# Altium schematic sheet BluePhilSch.SchDoc(BluePhilSch)
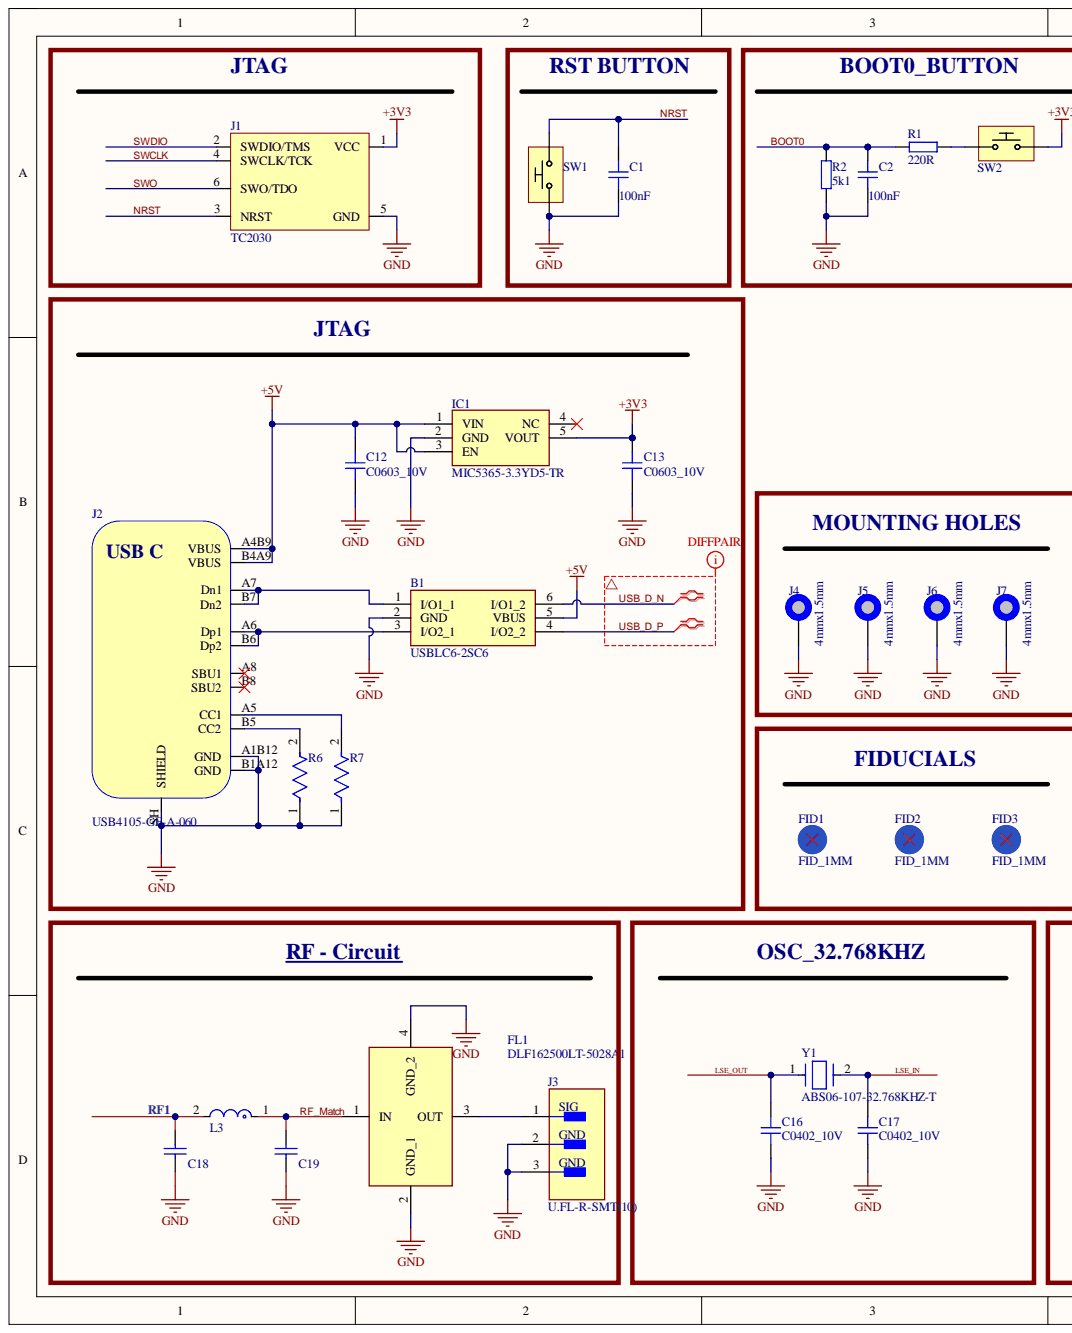
[diagram p0: BluePhilSch.SchDoc, left]
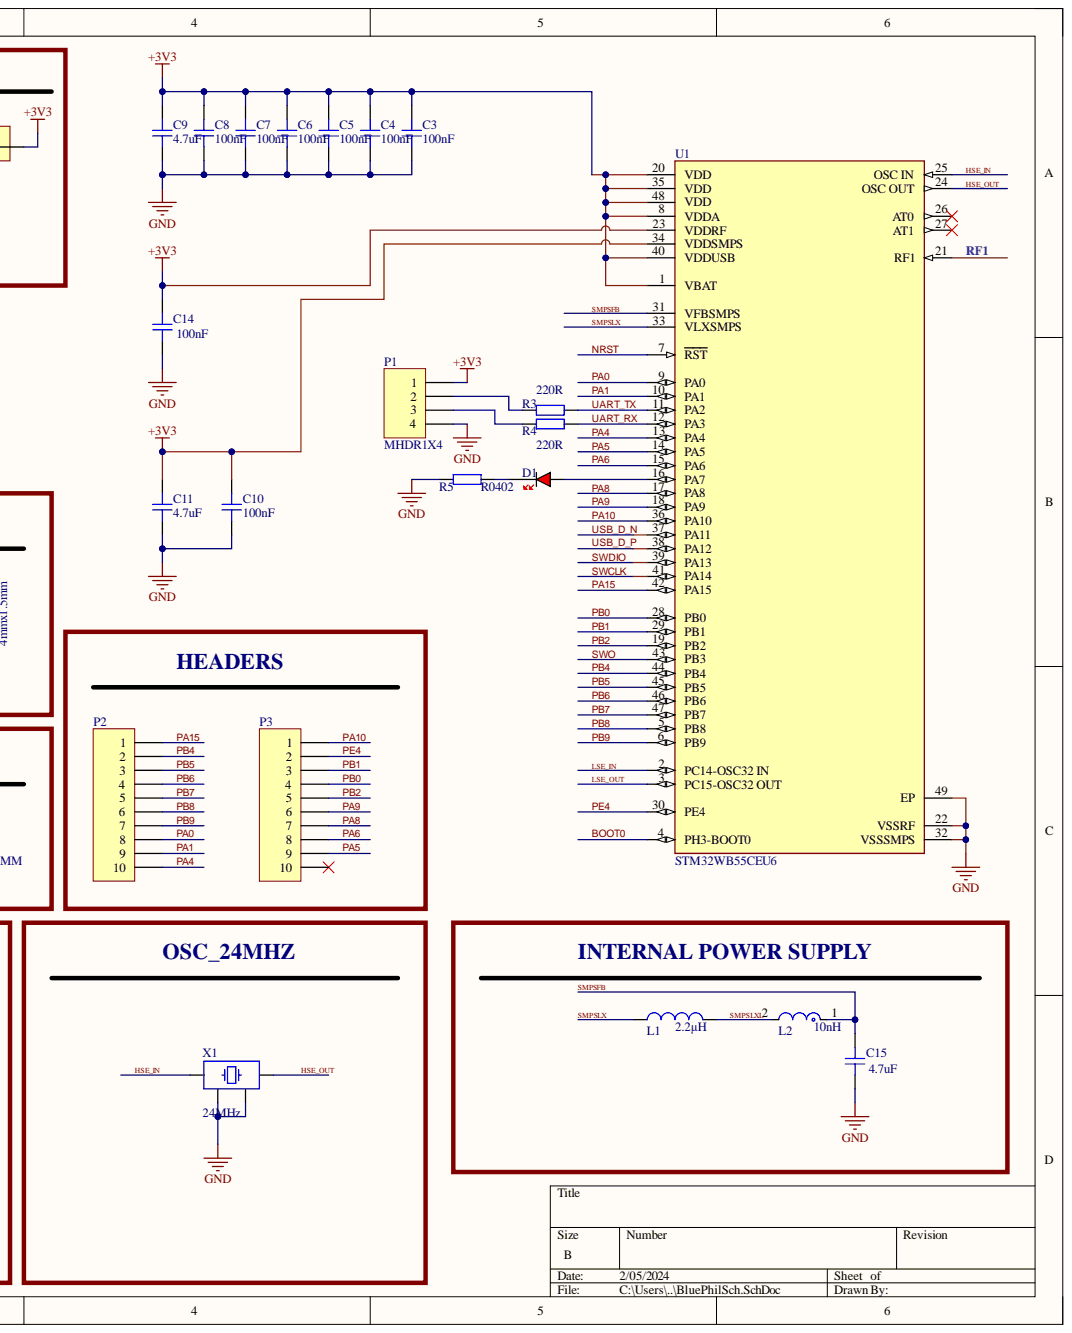
[diagram p1: BluePhilSch.SchDoc, right]

## Parts
B1  USBLC6-2SC6  [SOT95P280X145-6N]
C1  C0402_10V  value 100nF  [CAP_0402]
C2  C0402_10V  value 100nF  [CAP_0402]
C3  C0402_10V  value 100nF  [CAP_0402]
C4  C0402_10V  value 100nF  [CAP_0402]
C5  C0402_10V  value 100nF  [CAP_0402]
C6  C0402_10V  value 100nF  [CAP_0402]
C7  C0402_10V  value 100nF  [CAP_0402]
C8  C0402_10V  value 100nF  [CAP_0402]
C9  C0402_10V  value 4.7uF  [CAP_0402]
C10  C0402_10V  value 100nF  [CAP_0402]
C11  C0402_10V  value 4.7uF  [CAP_0402]
C12  C0603_10V  [CAP_0603]
C13  C0603_10V  [CAP_0603]
C14  C0402_10V  value 100nF  [CAP_0402]
C15  C0402_10V  value 4.7uF  [CAP_0402]
C16  C0402_10V  [CAP_0402]
C17  C0402_10V  [CAP_0402]
C18  GRM1555C1HR80BA01D  [CAPC1005X55X25LL05T10]  (value not drawn)
C19  GRM1555C1HR30WA01D  [CAPC1005X55X25NL05T10]  (value not drawn)
D1  RED  [LED_0603_RED]  (value not drawn)
FID1  FID_1MM  [FID_40X120D]
FID2  FID_1MM  [FID_40X120D]
FID3  FID_1MM  [FID_40X120D]
FL1  DLF162500LT-5028A1  [DLF162500LT5028A1]
IC1  MIC5365-3.3YD5-TR  [SOT95P280X100-5N]
J1  TC2030  [TC2030-FP]  (no BOM)
J2  USB4105-GF-A-060  [USB4105-GF-A-060]
J3  U.FL-R-SMT(10)  [FP-U_FL-R-SMT_10-MFG]
J4  4mmx1.5mm  [PAD_WITH_HOLE_4mmx1.5mm]  (no BOM)
J5  4mmx1.5mm  [PAD_WITH_HOLE_4mmx1.5mm]  (no BOM)
J6  4mmx1.5mm  [PAD_WITH_HOLE_4mmx1.5mm]  (no BOM)
J7  4mmx1.5mm  [PAD_WITH_HOLE_4mmx1.5mm]  (no BOM)
L1  Inductance: 2.2µH  [WE-PMI_0805_L2W1.2H0.5]  (hidden comment: 74479774222)
L2  Inductance: 10nH  [FP-LQG15WH_02-MFG]  (hidden comment: LQG15WZ10NJ02D)
L3  LQG15HS2N7S02D  [FP-LQG15HH_02-IPC_C]  (value not drawn)
P1  MHDR1X4  [MHDR1X4body]
P2  Header 10  [MY_MA10-1]  (value not drawn)
P3  Header 10  [MY_MA10-1]  (value not drawn)
R1  220R  [RES_0402]
R2  5k1  [RES_0402]
R3  220R  [RES_0402]
R4  220R  [RES_0402]
R5  R0402  [RES_0402]
R6  ERJ-2RKF5101X  [FP-ERJ2RK-IPC_A]  (value not drawn)
R7  ERJ-2RKF5101X  [FP-ERJ2RK-IPC_A]  (value not drawn)
SW1  Tactile Switch  [PTS815]  (value not drawn)
SW2  Tactile Switch  [PTS815]  (value not drawn)
U1  STM32WB55CEU6  [FP-UFQFPN48-A0B9-MFG]
X1  24MHz  [XTAL_3225]
Y1  ABS06-107-32.768KHZ-T  [FP-ABS06-MFG]

## Nets
+3V3: C3.1 C4.1 C5.1 C6.1 C7.1 C8.1 C9.1 C10.1 C11.1 C13.2 C14.1 IC1.5(VOUT) J1.1(VCC) P1.1 SW2.2 U1.1(VBAT) U1.8(VDDA) U1.20(VDD) U1.23(VDDRF) U1.34(VDDSMPS) U1.35(VDD) U1.40(VDDUSB) U1.48(VDD)
+5V: B1.5(VBUS) C12.2 IC1.1(VIN) IC1.3(EN) J2.A4B9(VBUS) J2.B4A9(VBUS)
GND: B1.2(GND) C1.2 C2.2 C3.2 C4.2 C5.2 C6.2 C7.2 C8.2 C9.2 C10.2 C11.2 C12.1 C13.1 C14.2 C15.2 C16.1 C17.1 C18.1 C19.2 FL1.2(GND_1) FL1.4(GND_2) IC1.2(GND) J1.5(GND) J2.A1B12(GND) J2.B1A12(GND) J2.SH(SHIELD) J3.2 J3.3 J4.1 J5.1 J6.1 J7.1 P1.4 R2.2 R5.1 R6.1 R7.1 SW1.1 U1.22(VSSRF) U1.32(VSSSMPS) U1.49(EP) X1.2 X1.4
BOOT0: C2.1 R1.1 R2.1 U1.4(PH3-BOOT0)
HSE_IN: U1.25(OSC_IN) X1.1
HSE_OUT: U1.24(OSC_OUT) X1.3
LSE_IN: C17.2 U1.2(PC14-OSC32_IN) Y1.2
LSE_OUT: C16.2 U1.3(PC15-OSC32_OUT) Y1.1
NRST: C1.1 J1.3(NRST) SW1.2 U1.7(RST)
PA0: P2.8 U1.9(PA0)
PA1: P2.9 U1.10(PA1)
PA4: P2.10 U1.13(PA4)
PA5: P3.9 U1.14(PA5)
PA6: P3.8 U1.15(PA6)
PA8: P3.7 U1.17(PA8)
PA9: P3.6 U1.18(PA9)
PA10: P3.1 U1.36(PA10)
PA15: P2.1 U1.42(PA15)
PB0: P3.4 U1.28(PB0)
PB1: P3.3 U1.29(PB1)
PB2: P3.5 U1.19(PB2)
PB4: P2.2 U1.44(PB4)
PB5: P2.3 U1.45(PB5)
PB6: P2.4 U1.46(PB6)
PB7: P2.5 U1.47(PB7)
PB8: P2.6 U1.5(PB8)
PB9: P2.7 U1.6(PB9)
PE4: P3.2 U1.30(PE4)
RF1: C18.2 L3.2 U1.21(RF1)
RF_Match: C19.1 FL1.1(IN) L3.1
SMPSFB: C15.1 L2.1 U1.31(VFBSMPS)
SMPSLX: L1.2 U1.33(VLXSMPS)
SMPSLXL: L1.1 L2.2
SWCLK: J1.4(SWCLK/TCK) U1.41(PA14)
SWDIO: J1.2(SWDIO/TMS) U1.39(PA13)
SWO: J1.6(SWO/TDO) U1.43(PB3)
UART_RX: R4.2 U1.12(PA3)
UART_TX: R3.2 U1.11(PA2)
USB_D_N: B1.6(I/O1_2) U1.37(PA11)
USB_D_P: B1.4(I/O2_2) U1.38(PA12)
NetB1_1: B1.1(I/O1_1) J2.A7(Dn1) J2.B7(Dn2)
NetB1_3: B1.3(I/O2_1) J2.A6(Dp1) J2.B6(Dp2)
NetD1_A: D1.A U1.16(PA7)
NetD1_K: D1.K R5.2
NetFL1_3: FL1.3(OUT) J3.1
NetJ2_A5: J2.A5(CC1) R7.2
NetJ2_B5: J2.B5(CC2) R6.2
NetP1_2: P1.2 R3.1
NetP1_3: P1.3 R4.1
NetR1_2: R1.2 SW2.1

Not connected: IC1 4(NC); J2 A8(SBU1) B8(SBU2); P3 10; U1 26(AT0) 27(AT1)

## Library data of the parts
B1 = USBLC6-2SC6: TVS Diode Array Uni-Directional USBLC6-2SC6 17V, SOT-23 6-Pin; Manufacturer_Name: STMicroelectronics; Geometry.Height: 1.45mm; Component Kind: Standard; Datasheet Link: http://www.st.com/st-web-ui/static/active/en/resource/technical/document/datasheet/CD00050750.pdf; Library Name: USBLC6-2SC6.SchLib; Manufacturer_Part_Number: USBLC6-2SC6; Mouser Part Number: 511-USBLC6-2SC6; Mouser Price/Stock: https://www.mouser.co.uk/ProductDetail/STMicroelectronics/USBLC6-2SC6?qs=po45yt2pPpu%2FhNIlwQdTlg%3D%3D
C1-C11 C14-C17 = CAP_0402_10V: Capacitor SMD 0402 10V; Component Kind: Standard; Library Name: Schematic-Lib-PhilsLab.SchLib
C12 C13 = CAP_0603_10V: Capacitor SMD 0603 10V; Component Kind: Standard; Library Name: Schematic-Lib-PhilsLab.SchLib
C18 = CMP-1034-00043-2: Manufacturer: Murata; Component Kind: Standard; Library Name: Altium Content Vault; Part Number: GRM1555C1HR80BA01D
C19 = CMP-1034-00007-2: Manufacturer: Murata; Component Kind: Standard; Library Name: Altium Content Vault; Part Number: GRM1555C1HR30WA01D
D1 = LED_0603_RED: LED 0603 RED SMD; Component Kind: Standard; Library Name: Schematic-Lib-PhilsLab.SchLib
FL1 = DLF162500LT-5028A1: Filter; Manufacturer_Name: TDK; Arrow Part Number: DLF162500LT-5028A1; Arrow Price/Stock: https://www.arrow.com/en/products/dlf162500lt-5028a1/tdk?region=europe; Component Kind: Standard; Datasheet Link: https://product.tdk.com/system/files/dam/doc/product/rf/rf/filter/catalog/rf_lpf_dlf162500lt-5028a1_en.pdf; Height: 0.4mm; Manufacturer_Part_Number: DLF162500LT-5028A1; Mouser Part Number: 810-DLF16250LT5028A1; Mouser Price/Stock: https://www.mouser.co.uk/ProductDetail/TDK/DLF162500LT-5028A1?qs=U%2FZX79kHR%2FlwIbAISCs3qA%3D%3D
IC1 = MIC5365-3.3YD5-TR: Integrated Circuit; Manufacturer_Name: Microchip; Arrow Part Number: MIC5365-3.3YD5-TR; Arrow Price/Stock: https://www.arrow.com/en/products/mic5365-3.3yd5-tr/microchip-technology?region=nac; Component Kind: Standard; Datasheet Link: https://ww1.microchip.com/downloads/en/DeviceDoc/mic5365.pdf; Height: 1mm; Manufacturer_Part_Number: MIC5365-3.3YD5-TR; Mouser Part Number: 998-MIC5365-3.3YD5TR; Mouser Price/Stock: https://www.mouser.co.uk/ProductDetail/Microchip-Technology/MIC5365-3.3YD5-TR?qs=U6T8BxXiZAXzRinbbfZRrg%3D%3D
J1 = TC2030: Tag-Connect No-Solder SWD Debug Connector DO NOT PLACE; Manufacturer: Tag-Connect; Manufacturer Part Number: TC2030; Library Name: Schematic-Lib-PhilsLab.SchLib
J2 = USB4105-GF-A-060: USB Connector Type C SMT 12 Pin; Manufacturer: GCT; Component Kind: Standard; Manufacturer Part Number: USB4105-GF-A-060; Library Name: Schematic-Lib-PhilsLab.SchLib
J3 = CMP-0029-00003-2: CONN U.FL RCPT STR 50 OHM SMD; Body Material: Bronze; Body Plating: Silver; Connector Type: Coaxial; Dielectric Material: Polymer; Frequency: 6GHz; Impedance: 50R; Case/Package: SMD/SMT; Component Kind: Standard; Contact Material: Brass; Contact Plating: Gold; Depth: 3.1mm; Fastening Type: Snap; Housing Color: Beige; Lead Free: Lead Free; Length: 3mm; Library Name: Altium Content Vault; Max Frequency: 6GHz; Max Operating Temperature: 90°C; Min Operating Temperature: -40°C; Mount: Surface Mount; Orientation: Vertical; Package Quantity: 1; Packaging: Tape and Reel; Plating: Silver; Radiation Hardening: No; Termination: Solder
J4-J7 = PAD_WITH_HOLE_4mmx1.5mm: Library Name: Schlib1.SchLib
L1 = CMP-1392-00044-3: NexarPartId: 23392509; Current Rating: 700mA; DC Resistance (DCR): 340mΩ; Inductance Tolerance: 20%; Q Factor: 15; Saturation Current: 250mA; Self Resonant Frequency: 40MHz; Series Resistance: 425mΩ; Test Frequency: 1MHz; Ambient Temperature Range High: 85°C; Ambient Temperature Range Low: -40°C; Case/Package: 0805; Component Kind: Standard; Height: 500µm; Length: 2mm; Library Name: Altium Content Vault; Max Operating Temperature: 125°C; Min Operating Temperature: -40°C; Number of Pins: 2; Packaging: Digi-Reel®; RMS Current (Irms): 700mA; RoHS: Compliant; Tolerance: 20%; Width: 1.25mm
L2 = CMP-06042-005237-1: Multilayer type Inductor for Automotive 10nH ±5% 0.19Ω 500mA 0402; Core Material: Air; DC Resistance (DCR): 190mR; Self Resonant Frequency: 3.3GHz; Shielding: Unshielded; Test Frequency: 100MHz; Case Code (Imperial): 0402; Case Code (Metric): 1005; Case/Package: 0402; Component Kind: Standard; Current Rating: 500mA; Library Name: Altium Content Vault; Max Operating Temperature: 125°C; Min Operating Temperature: -55°C; Mount: Surface Mount; Packaging: Cut Tape; Ratings: AEC-Q200; Tolerance: 5%
L3 = CMP-2000-06580-2: Multilayer type RF Inductor 2.7nH ±0.3nH 0.12Ω 800mA 0402; Core Material: Air; Current Rating: 300mA; DC Resistance (DCR): 150mR; Q Factor: 8; Self Resonant Frequency: 6GHz; Shielding: Unshielded; Test Frequency: 100MHz; Case Code (Imperial): 0402; Case Code (Metric): 1005; Case/Package: 0402; Component Kind: Standard; DC Current: 300mA; Depth: 0.55mm; Height: 0.5mm; Height - Seated (Max): 0.022inch; Inductance: 2.7nH; Lead Free: Lead Free; Length: 1mm; Library Name: Altium Content Vault; Max DC Current: 300mA; Max Operating Temperature: 125°C; Military Standard: Not; Min Operating Temperature: -55°C; Mount: Surface Mount; Package Quantity: 10000; Packaging: Tape and Reel; Radiation Hardening: No; Termination: SMD/SMT; Width: 0.5mm
P1 = MHDR1X4: Header, 4-Pin; Component Kind: Standard; LatestRevisionDate: 17-Jul-2002; LatestRevisionNote: Re-released for DXP Platform.; Library Name: smartmcu.IntLib; Publisher: Altium Limited
P2 P3 = Header 10: Header, 10-Pin; ASiD Part Number: Header 10-AS; Component Kind: Standard; Library Name: Mylib+Rev+4.IntLib; Signal Integrity: Connector
R1-R5 = RES_0402: Resistor SMD 0402; Component Kind: Standard; Library Name: Schematic-Lib-PhilsLab.SchLib
R6 R7 = CMP-2002-01158-2: RES SMD 5.1K OHM 1% 1/10W 0402; Max Power Dissipation: 100mW; Resistance: 5.1kR; Temperature Coefficient: 100ppm/°C; Voltage Rating: 50V; Case Code (Imperial): 0402; Case Code (Metric): 1005; Case/Package: 0402; Component Kind: Standard; Construction: Rectangular; Contact Plating: Tin; Depth: 0.5mm; Height: 0.35mm; Lead Free: Lead Free; Length: 1mm; Library Name: Altium Content Vault; Max Operating Temperature: 155°C; Military Standard: Not; Min Operating Temperature: -55°C; Mount: Surface Mount; Number of Terminations: 2; Package Quantity: 10000; Packaging: Tape and Reel; Power Rating: 100mW; REACH SVHC: No SVHC; Resistance Tolerance: 1%; Resistor Type: Thick Film; RoHS Compliant: Yes; Series: ERJ; Technology: THICK FILM; Termination: SMD/SMT; Tolerance: 1%; Voltage Rating (DC): 50V; Weight: 2.8E-05oz; Width: 0.5mm
SW1 SW2 = PTS815-SJM-250-SMTR-LFS: Tactile Switches Tact Switch, 12 VDC, 50 mA, 4.2x3.2, 2.5mm H, 180gf, J leadspp SPST; Manufacturer: C&K Switches; Manufacturer Part Number: PTS815 SJM 250 SMTR LFS; Component Kind: Standard; Library Name: Schematic-Lib-PhilsLab.SchLib
U1 = CMP-12160-000002-1: ULTRA-LOW-POWER DUAL CORE ARM CO; Manufacturer: STMicroelectronics; Bandwidth: 2402-2480MHz; Data Rate: 2Mbits/s; Interface: I2C,SPI,UART,USART,USB,Other; Max Frequency: 2480MHz; Max Junction Temp: +125°C; Max Power Dissipation: 392mW; Max Supply Voltage: 3.6V; Min Supply Voltage: 1.71V; Number Of Adc Channels: 13; Number Of Gpio: 30; Sensitivity: -100dBm; Standoff Height: 0mm; Ambient Temperature Range High: +105°C; Ambient Temperature Range Low: -40°C; Automotive: No; Category: IC; Component Kind: Standard; ComponentLink2Description: Datasheet; ComponentLink2URL: https://www.st.com/resource/en/datasheet/stm32wb55rg.pdf; Device Class L1: Integrated Circuits (ICs); Device Class L2: RF Semiconductors and Devices; Device Class L3: Transceivers; Digikey Description: ULTRA-LOW-POWER DUAL CORE ARM CO; Height: 0.65mm; Ipc Land Pattern Name: QFN50P700X700X57-48; Lead Free: Yes; Library Name: Altium Content Vault; Manufacturer Part Number: STM32WB55CEU6; Octopart: https://octopart.com/stm32wb55ceu6-stmicroelectronics-96611730; Package: UFQFPN48-A0B9; RoHS: TRUE; Temperature: -40°C to +125°C; Temperature Range High: +125°C; Temperature Range Low: -40°C
X1 = TSX-3225_24.0000MF15X-AC: Crystal SMD 24MHz 10PPM 7pF 3225; Manufacturer: Epson; Manufacturer Part Number: TSX-3225 24.0000MF15X-AC; Component Kind: Standard; Library Name: Schematic-Lib-PhilsLab.SchLib
Y1 = CMP-2000-05734-3: Crystal 32.768kHz ±20ppm (Tol) 4pF FUND 60kΩ 2-Pin Mini-CSMD T/R; ESR (Equivalent Series Resistance): 60kR; Frequency Stability: 175ppm; Series Resistance: 80kR; Case Code (Imperial): 0805; Case Code (Metric): 2012; Case/Package: SMD/SMT; Component Kind: Standard; Frequency: 32.768kHz; Frequency Tolerance: 20ppm; Height: 0.6mm; Lead Free: Lead Free; Length: 2mm; Library Name: Altium Content Vault; Load Capacitance: 4pF; Max Operating Temperature: 85°C; Min Operating Temperature: -40°C; Mount: Surface Mount; Number of Pins: 2; Package Quantity: 3000; Packaging: Tape and Reel; Radiation Hardening: No; RoHS Compliant: Yes; Series: ABS06; Termination: SMD/SMT; Tolerance: 20ppm; Width: 1.2mm
FID1-FID3 = FID_1MM: Component Kind: Standard; Library Name: Schlib1.SchLib
